# Altium schematic sheet Estágio 1 - Diferencial.SchDoc
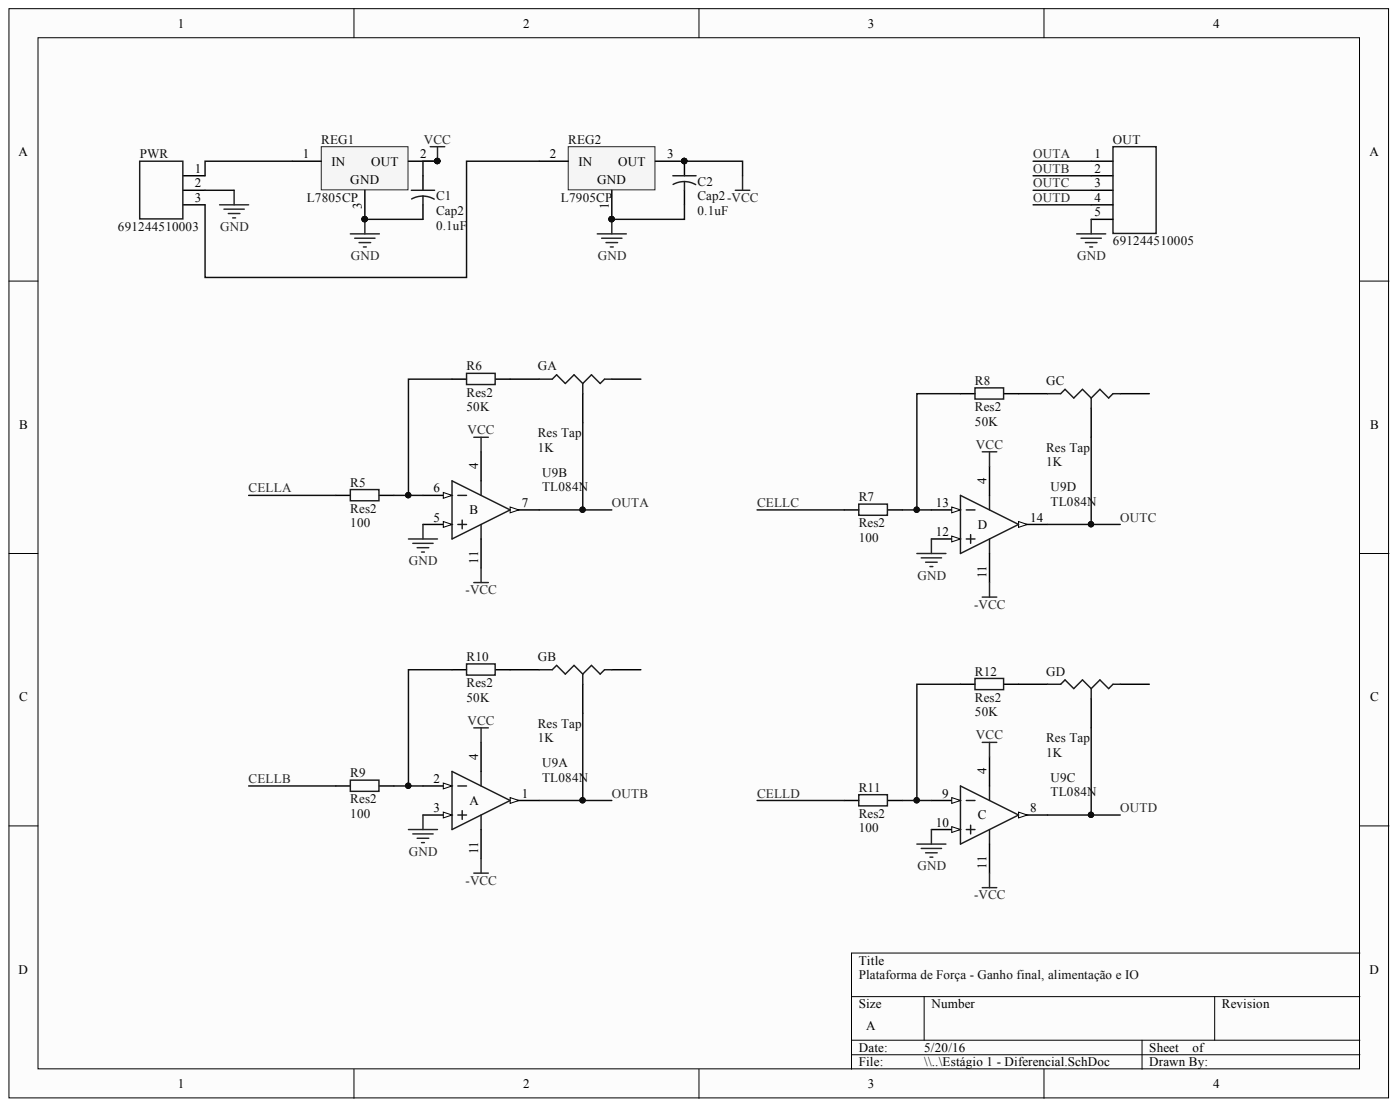
[diagram p0: Estágio 1 - Diferencial.SchDoc]

## Parts
C1  Cap2  value 0.1uF  [CAPR5-4X5]
C2  Cap2  value 0.1uF  [CAPR5-4X5]
GA  Res Tap  value 1K  [TSR3006]
GB  Res Tap  value 1K  [TSR3006]
GC  Res Tap  value 1K  [TSR3006]
GD  Res Tap  value 1K  [TSR3006]
OUT  691244510005  [691244510005]
PWR  691244510003  [691244510003]
R5  Res2  value 100  [AXIAL-0.4]
R6  Res2  value 50K  [AXIAL-0.4]
R7  Res2  value 100  [AXIAL-0.4]
R8  Res2  value 50K  [AXIAL-0.4]
R9  Res2  value 100  [AXIAL-0.4]
R10  Res2  value 50K  [AXIAL-0.4]
R11  Res2  value 100  [AXIAL-0.4]
R12  Res2  value 50K  [AXIAL-0.4]
REG1  L7805CP  [ISOWATT220AB]
REG2  L7905CP  [ISOWATT220AB]
U9  TL084N  [N014]  (parts A,B,C,D)

## Nets
-VCC: C2.1 REG2.3 U9.11
GND: C1.2 C2.2 OUT.5 PWR.2 REG1.3 REG2.1 U9.3 U9.5 U9.10 U9.12
VCC: C1.1 REG1.2 U9.4
CELLA: R5.1
CELLB: R9.1
CELLC: R7.1
CELLD: R11.1
OUTA: GA.3 OUT.1 U9.7
OUTB: GB.3 OUT.2 U9.1
OUTC: GC.3 OUT.3 U9.14
OUTD: GD.3 OUT.4 U9.8
NetGA_2: GA.2 R6.2
NetGB_2: GB.2 R10.2
NetGC_2: GC.2 R8.2
NetGD_2: GD.2 R12.2
NetPWR_1: PWR.1 REG1.1
NetPWR_3: PWR.3 REG2.2
NetR5_2: R5.2 R6.1 U9.6
NetR7_2: R7.2 R8.1 U9.13
NetR9_2: R9.2 R10.1 U9.2
NetR11_2: R11.2 R12.1 U9.9

Not connected: GA 1; GB 1; GC 1; GD 1

## Library data of the parts
C1 C2 = Cap2: Capacitor; Component Kind: Standard; Library Name: Miscellaneous Devices.IntLib; PackageDescription: Capacitor; 2 Leads; PackageReference: CAPR5-4X5; Published: 23-Sep-2002; Signal Integrity: Cap; Simulation: CAP; Publisher: Altium Limited
GA GC GD = Res Tap: Tapped Resistor; Set Position: 0.5; Sim Note: Enter the Resistance value and the set point into parameter fields.; Component Kind: Standard; LatestRevisionDate: 17-Jul-2002; LatestRevisionNote: Re-released for DXP Platform.; Library Name: Miscellaneous Devices.IntLib; PackageDescription: Variable Resistor, Thru-Hole; 3 Leads; PackageReference: VR3; Published: 24-Mar-1999; Signal Integrity: Res Tap; Simulation: POT; Publisher: Altium Limited
GB = Res Tap: Tapped Resistor; Set Position: 0.5; Sim Note: Enter the Resistance value and the set point into parameter fields.; Value: 1K; Component Kind: Standard; LatestRevisionDate: 17-Jul-2002; LatestRevisionNote: Re-released for DXP Platform.; Library Name: Miscellaneous Devices.IntLib; PackageDescription: Variable Resistor, Thru-Hole; 3 Leads; PackageReference: VR3; Published: 24-Mar-1999; Signal Integrity: Res Tap; Simulation: POT; Publisher: Altium Limited
OUT = 691244510005: WR-TBL Horizontal Cable Entry With Rising Cage Clamp,Serie 2445 - 5.08 mm; Manufacturer: Wurth Elektronik; ComponentLink1 Description: Manufacturer; ComponentLink2 Description: Datasheet; Component Kind: Standard; ComponentLink1URL: http://www.we-online.de; ComponentLink2URL: http://katalog.we-online.de/em/datasheet/6912445100XX.pdf; Created Date: 15.Sep.2015; Library Name: WE_WR-TBL_Rising Cage System_5.08 mm_rev15b.IntLib; partNo: 691244510005; Created by: Baojun Chen
PWR = 691244510003: WR-TBL Horizontal Cable Entry With Rising Cage Clamp,Serie 2445 - 5.08 mm; Manufacturer: Wurth Elektronik; ComponentLink1 Description: Manufacturer; ComponentLink2 Description: Datasheet; Component Kind: Standard; ComponentLink1URL: http://www.we-online.de; ComponentLink2URL: http://katalog.we-online.de/em/datasheet/6912445100XX.pdf; Created Date: 15.Sep.2015; Library Name: WE_WR-TBL_Rising Cage System_5.08 mm_rev15b.IntLib; partNo: 691244510003; Created by: Baojun Chen
R5-R12 = Res2: Resistor; Component Kind: Standard; LatestRevisionDate: 17-Jul-2002; LatestRevisionNote: Re-released for DXP Platform.; Library Name: Miscellaneous Devices.IntLib; PackageDescription: Axial Device, Thru-Hole; 2 Leads; 0.4 in Pin Spacing; PackageReference: AXIAL-0.4; Published: 8-Jun-2000; Signal Integrity: Res; Simulation: RESISTOR; Publisher: Altium Limited
REG1 = L7805CP: Positive Voltage Regulator; Note: Tab is connected to pin 3 (GND).; Component Kind: Standard; ComponentLink1Description: Manufacturer Link; ComponentLink1URL: http://www.st.com/; DatasheetVersion: Dec-1998; LatestRevisionDate: 17-Jul-2002; LatestRevisionNote: Re-released for DXP Platform.; Library Name: ST Power Mgt Voltage Regulator.IntLib; PackageDescription: TO, Thru-Hole, Vertical, Heatsink Mounted; 3 In-Line Leads; Pitch 2.54 mm; Pin sequence 1, 3, 2; PackageReference: ISOWATT220AB; PackageVersion: Jul-1998; Published: 24-Aug-2001; Publisher: Altium Limited
REG2 = L7905CP: Negative Voltage Regulator; Note: Tab is connected to pin 3 (IN).; Component Kind: Standard; ComponentLink1Description: Manufacturer Link; ComponentLink1URL: http://www.st.com/; ComponentLink2Description: Datasheet; ComponentLink2URL: http://www.st.com/stonline/products/literature/ds/2149/l7905c.pdf; DatasheetVersion: Apr-1997; LatestRevisionDate: 17-Jul-2002; LatestRevisionNote: Re-released for DXP Platform.; Library Name: ST Power Mgt Voltage Regulator.IntLib; PackageDescription: TO, Thru-Hole, Vertical, Heatsink Mounted; 3 In-Line Leads; Pitch 2.54 mm; Pin sequence 1, 3, 2; PackageReference: ISOWATT220AB; PackageVersion: Jul-1998; Published: 24-Aug-2001; Publisher: Altium Limited
U9 = TL084N: JFET-Input Operational Amplifier; Component Kind: Standard; ComponentLink1Description: Manufacturer Link; ComponentLink1URL: http://www.ti.com/; ComponentLink2Description: Datasheet; ComponentLink2URL: http://www-s.ti.com/sc/ds/tl084.pdf; DatasheetVersion: Feb-1999; LatestRevisionDate: 04-Mar-2005; LatestRevisionNote: Stylized 3D Model Added.; Library Name: TI Operational Amplifier.IntLib; PackageDescription: DIP; 14 Leads; Row Spacing 7.62 mm; Pitch 2.54 mm; PackageReference: N014; PackageVersion: Oct-1995; PCB3D: N014; Published: 16-Jan-2001; Simulation: TL084; Publisher: Altium Limited
